# Altium schematic sheet MCU.SchDoc(MCU)
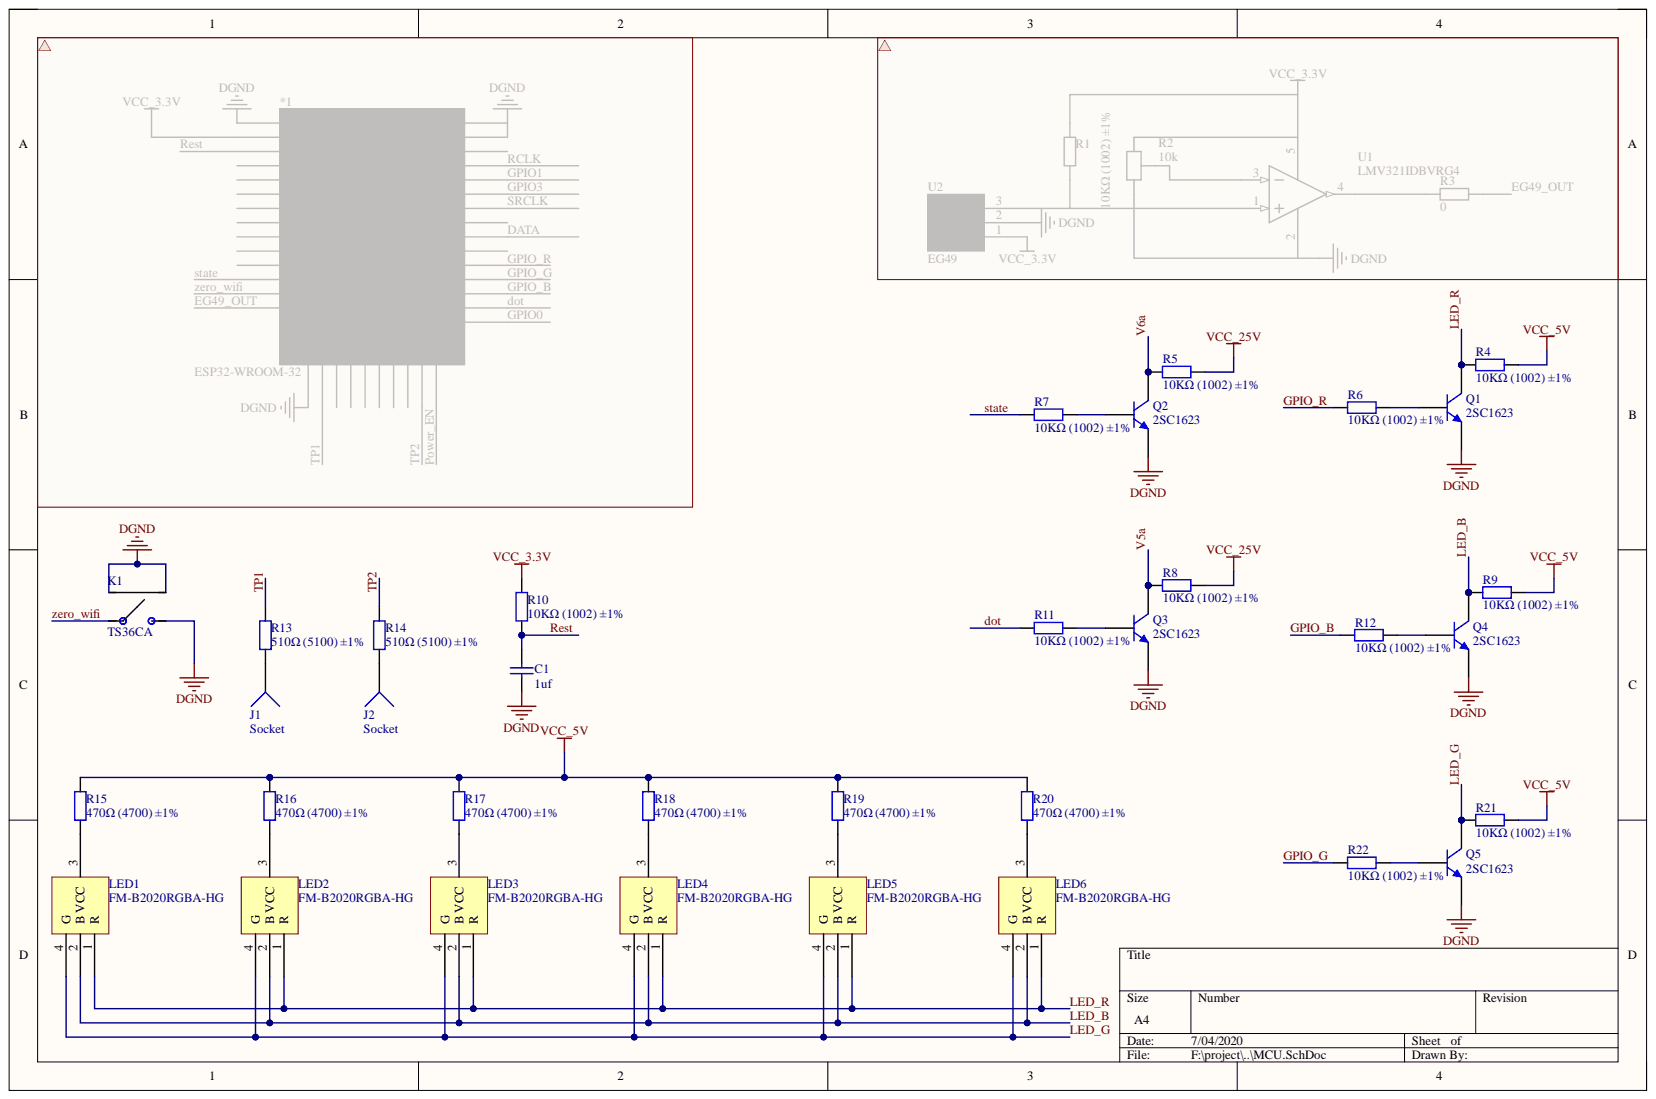
[diagram p0: MCU.SchDoc]

## Parts
C1  1uf  [0603_C]
J1  Socket  [Key]
J2  Socket  [Key]
K1  TS36CA  [SW-SMD_TS36CA]
LED1  FM-B2020RGBA-HG  [LED-2020_4P]
LED2  FM-B2020RGBA-HG  [LED-2020_4P]
LED3  FM-B2020RGBA-HG  [LED-2020_4P]
LED4  FM-B2020RGBA-HG  [LED-2020_4P]
LED5  FM-B2020RGBA-HG  [LED-2020_4P]
LED6  FM-B2020RGBA-HG  [LED-2020_4P]
Q1  2SC1623  [SOT-23(SOT-23-3)]
Q2  2SC1623  [SOT-23(SOT-23-3)]
Q3  2SC1623  [SOT-23(SOT-23-3)]
Q4  2SC1623  [SOT-23(SOT-23-3)]
Q5  2SC1623  [SOT-23(SOT-23-3)]
R4  10KΩ (1002) ±1%  [0603_R]
R5  10KΩ (1002) ±1%  [0603_R]
R6  10KΩ (1002) ±1%  [0603_R]
R7  10KΩ (1002) ±1%  [0603_R]
R8  10KΩ (1002) ±1%  [0603_R]
R9  10KΩ (1002) ±1%  [0603_R]
R10  10KΩ (1002) ±1%  [0603_R]
R11  10KΩ (1002) ±1%  [0603_R]
R12  10KΩ (1002) ±1%  [0603_R]
R13  510Ω (5100) ±1%  [0603_R]
R14  510Ω (5100) ±1%  [0603_R]
R15  470Ω (4700) ±1%  [0603_R]
R16  470Ω (4700) ±1%  [0603_R]
R17  470Ω (4700) ±1%  [0603_R]
R18  470Ω (4700) ±1%  [0603_R]
R19  470Ω (4700) ±1%  [0603_R]
R20  470Ω (4700) ±1%  [0603_R]
R21  10KΩ (1002) ±1%  [0603_R]
R22  10KΩ (1002) ±1%  [0603_R]

## Nets
DGND: C1.1 K1.A K1.B K1.D Q1.2 Q2.2 Q3.2 Q4.2 Q5.2
VCC_3.3V: R10.2
VCC_5V: R4.2 R9.2 R15.2 R16.2 R17.2 R18.2 R19.2 R20.2 R21.2
VCC_25V: R5.2 R8.2
dot: R11.1
GPIO_B: R12.1
GPIO_G: R22.1
GPIO_R: R6.1
LED_B: LED1.2(B) LED2.2(B) LED3.2(B) LED4.2(B) LED5.2(B) LED6.2(B) Q4.3 R9.1
LED_G: LED1.4(G) LED2.4(G) LED3.4(G) LED4.4(G) LED5.4(G) LED6.4(G) Q5.3 R21.1
LED_R: LED1.1(R) LED2.1(R) LED3.1(R) LED4.1(R) LED5.1(R) LED6.1(R) Q1.3 R4.1
Rest: C1.2 R10.1
state: R7.1
TP1: R13.2
TP2: R14.2
V5a: Q3.3 R8.1
V6a: Q2.3 R5.1
zero_wifi: K1.C
NetJ1_1: J1.1 R13.1
NetJ2_1: J2.1 R14.1
NetLED1_3: LED1.3(VCC) R15.1
NetLED2_3: LED2.3(VCC) R16.1
NetLED3_3: LED3.3(VCC) R17.1
NetLED4_3: LED4.3(VCC) R18.1
NetLED5_3: LED5.3(VCC) R19.1
NetLED6_3: LED6.3(VCC) R20.1
NetQ1_1: Q1.1 R6.2
NetQ2_1: Q2.1 R7.2
NetQ3_1: Q3.1 R11.2
NetQ4_1: Q4.1 R12.2
NetQ5_1: Q5.1 R22.2

## Library data of the parts
C1 = 0603 1uF (105) 10% 50V: 贴片电容; Component Kind: Standard; ComponentLink1Description: 供应商链接; ComponentLink1URL: http://www.szlcsc.com/product/details_16531.html; Library Name: Miscellaneous Devices LC.IntLib; Package: 0603; Supplier: LC; SuppliersPartNumber: C15849
Q1-Q5 = SOT-23 SS8050 Y1: 三极管; Component Kind: Standard; Library Name: Miscellaneous Devices LC.IntLib; Package: SOT-23; Supplier: LC; SuppliersPartNumber: C2150
R4-R12 R21 R22 = 0603 10KΩ (1002) 1%: 贴片电阻; Component Kind: Standard; ComponentLink1Description: 供应商链接; ComponentLink1URL: http://www.szlcsc.com/product/details_26547.html; Library Name: Miscellaneous Devices LC.IntLib; Package: 0603; Supplier: LC; SuppliersPartNumber: C25804
R13 R14 = 0603 510Ω (5100) 1%: 贴片电阻; Component Kind: Standard; ComponentLink1Description: 供应商链接; ComponentLink1URL: http://www.szlcsc.com/product/details_23920.html; Library Name: Miscellaneous Devices LC.IntLib; Package: 0603; Supplier: LC; SuppliersPartNumber: C23193
R15-R20 = 0603 470Ω (4700) 1%: 贴片电阻; Component Kind: Standard; ComponentLink1Description: 供应商链接; ComponentLink1URL: http://www.szlcsc.com/product/details_23906.html; Library Name: Miscellaneous Devices LC.IntLib; Package: 0603; Supplier: LC; SuppliersPartNumber: C23179
J1 J2 = Socket: Component Kind: Standard; Description: Socket; Library Name: Miscellaneous Connectors.IntLib
K1 = TS36CA: Component Kind: Standard; Library Name: IV-3a.IntLib
LED1-LED6 = FM-B2020RGBA-HG: Component Kind: Standard; Library Name: IV-3a.IntLib
